# Altium schematic sheet Microcontrollers.SchDoc(Microcontrollers)
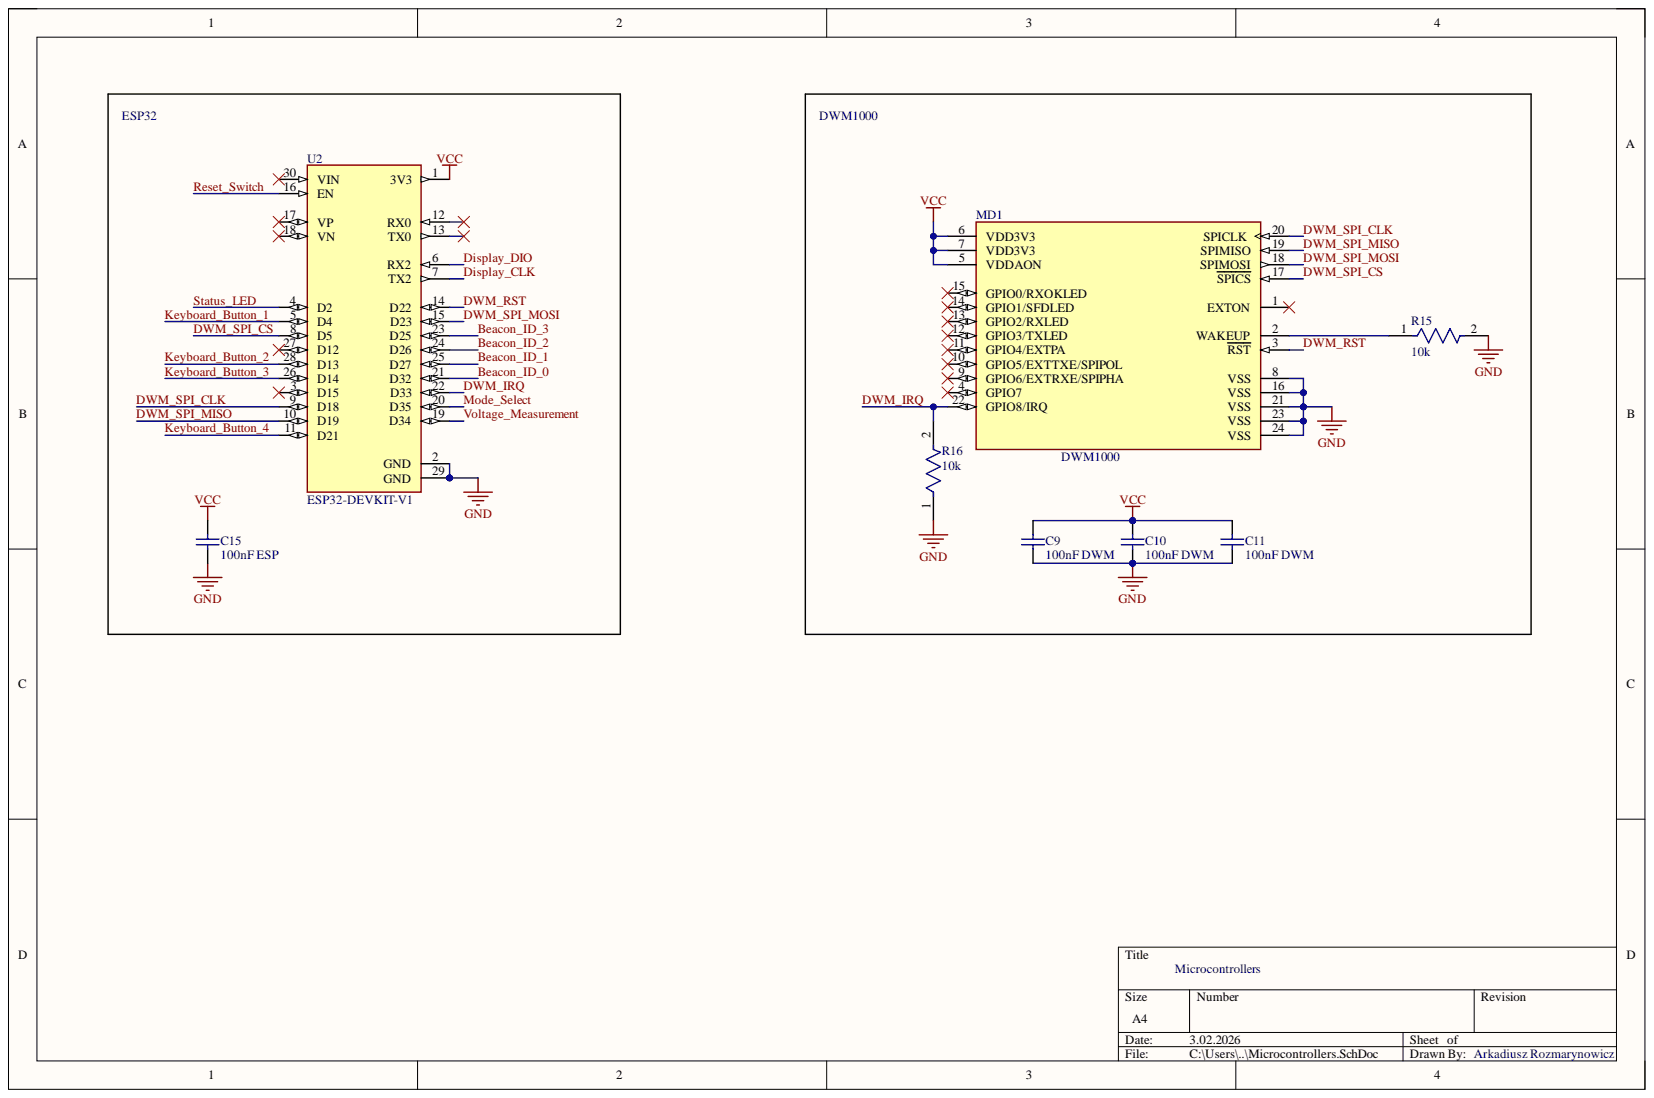
[diagram p0: Microcontrollers.SchDoc]

## Parts
C9  100nF DWM  [CAPC2013X145X48NL20T23]
C10  100nF DWM  [CAPC2013X145X48NL20T23]
C11  100nF DWM  [CAPC2013X145X48NL20T23]
C15  100nF ESP  [CAPC2013X145X48NL20T23]
MD1  DWM1000  [FP-DWM1000-MFG]
R15  10k  [FP-CRGCQ0805-IPC_C]
R16  10k  [FP-CRGCQ0805-IPC_C]
U2  ESP32-DEVKIT-V1  [MODULE_ESP32_DEVKIT_V1]

## Nets
GND: C9.1 C10.1 C11.1 C15.1 MD1.8(VSS) MD1.16(VSS) MD1.21(VSS) MD1.23(VSS) MD1.24(VSS) R15.2 R16.1 U2.2(GND) U2.29(GND)
VCC: C9.2 C10.2 C11.2 C15.2 MD1.5(VDDAON) MD1.6(VDD3V3) MD1.7(VDD3V3) U2.1(3V3)
Beacon_ID_0: U2.21(D32)
Beacon_ID_1: U2.25(D27)
Beacon_ID_2: U2.24(D26)
Beacon_ID_3: U2.23(D25)
Display_CLK: U2.7(TX2)
Display_DIO: U2.6(RX2)
DWM_IRQ: MD1.22(GPIO8/IRQ) R16.2 U2.22(D33)
DWM_RST: MD1.3(RST) U2.14(D22)
DWM_SPI_CLK: MD1.20(SPICLK) U2.9(D18)
DWM_SPI_CS: MD1.17(SPICS) U2.8(D5)
DWM_SPI_MISO: MD1.19(SPIMISO) U2.10(D19)
DWM_SPI_MOSI: MD1.18(SPIMOSI) U2.15(D23)
Keyboard_Button_1: U2.5(D4)
Keyboard_Button_2: U2.28(D13)
Keyboard_Button_3: U2.26(D14)
Keyboard_Button_4: U2.11(D21)
Mode_Select: U2.20(D35)
Reset_Switch: U2.16(EN)
Status_LED: U2.4(D2)
Voltage_Measurement: U2.19(D34)
NetMD1_2: MD1.2(WAKEUP) R15.1

Not connected: MD1 1(EXTON) 4(GPIO7) 9(GPIO6/EXTRXE/SPIPHA) 10(GPIO5/EXTTXE/SPIPOL) 11(GPIO4/EXTPA) 12(GPIO3/TXLED) 13(GPIO2/RXLED) 14(GPIO1/SFDLED) 15(GPIO0/RXOKLED); U2 3(D15) 12(RX0) 13(TX0) 17(VP) 18(VN) 27(D12) 30(VIN)

## Library data of the parts
C9-C11 C15 = CMP-2007-01705-1: Manufacturer: Vitramon; Part Number: VJ0805Y104KXAMR; Component Kind: Standard; Library Name: Altium Content Vault
MD1 = CMP-231853-000001-1: RX TXRX MODULE 802.15.4 CHIP SMD; Component Kind: Standard; Library Name: Altium Content Vault
R15 R16 = CMP-03211-009742-1: CRGCQ0805 10KΩ 0.125W ±100ppm/°C 5% 150V; Manufacturer: TE Connectivity; Manufacturer Part Number: CRGCQ0805J100K; Tolerance: 0.05; Ambient Temperature Range High: +70°C; Automotive: Yes; Automotive Grade: Grade 1142; Category: Res; Component Kind: Standard; ComponentLink2Description: Datasheet; ComponentLink2URL: https://www.te.com/commerce/DocumentDelivery/DDEController?Action=showdoc&DocId=Data+Sheet%7F1773204-3%7F1%7Fpdf%7FEnglish%7FENG_DS_1773204-3_1.pdf%7F4-2176341-1151; Composition: Thick Film; Device Class L1: Passive Components; Device Class L2: Resistors; Device Class L3: Chip SMD Resistors; Height: 0.65mm; Ipc Land Pattern Name: RESC200125X55; Lead Free: Yes; Library Name: Altium Content Vault; Octopart: https://octopart.com/crgcq0805j100k-te+connectivity-91018199; Package: CRGCQ0805; Power Rating: 0.125W; Resistance: 10k; RoHS: TRUE; Series: CRGCQ; Temperature: -55°C to +155°C; Temperature Coefficient: ±100ppm/°C; Temperature Range High: +155°C; Temperature Range Low: -55°C; Value: 10k; Voltage Rating: 150V
U2 = ESP32-DEVKIT-V1: Dual core, Wi-Fi: 2.4 GHz up to 150 Mbits/s,BLE (Bluetooth Low Energy) and legacy Bluetooth, 32 bits, Up to 240 MHz; MF: Do it; Check_prices: https://www.snapeda.com/parts/ESP32-DEVKIT-V1/Do+it/view-part/?ref=eda; Component Kind: Standard; Library Name: ESP32-DEVKIT-V1.IntLib; MP: ESP32-DEVKIT-V1; Package: None; SnapEDA_Link: https://www.snapeda.com/parts/ESP32-DEVKIT-V1/Do+it/view-part/?ref=snap
